# Altium schematic sheet MOTOR.SchDoc
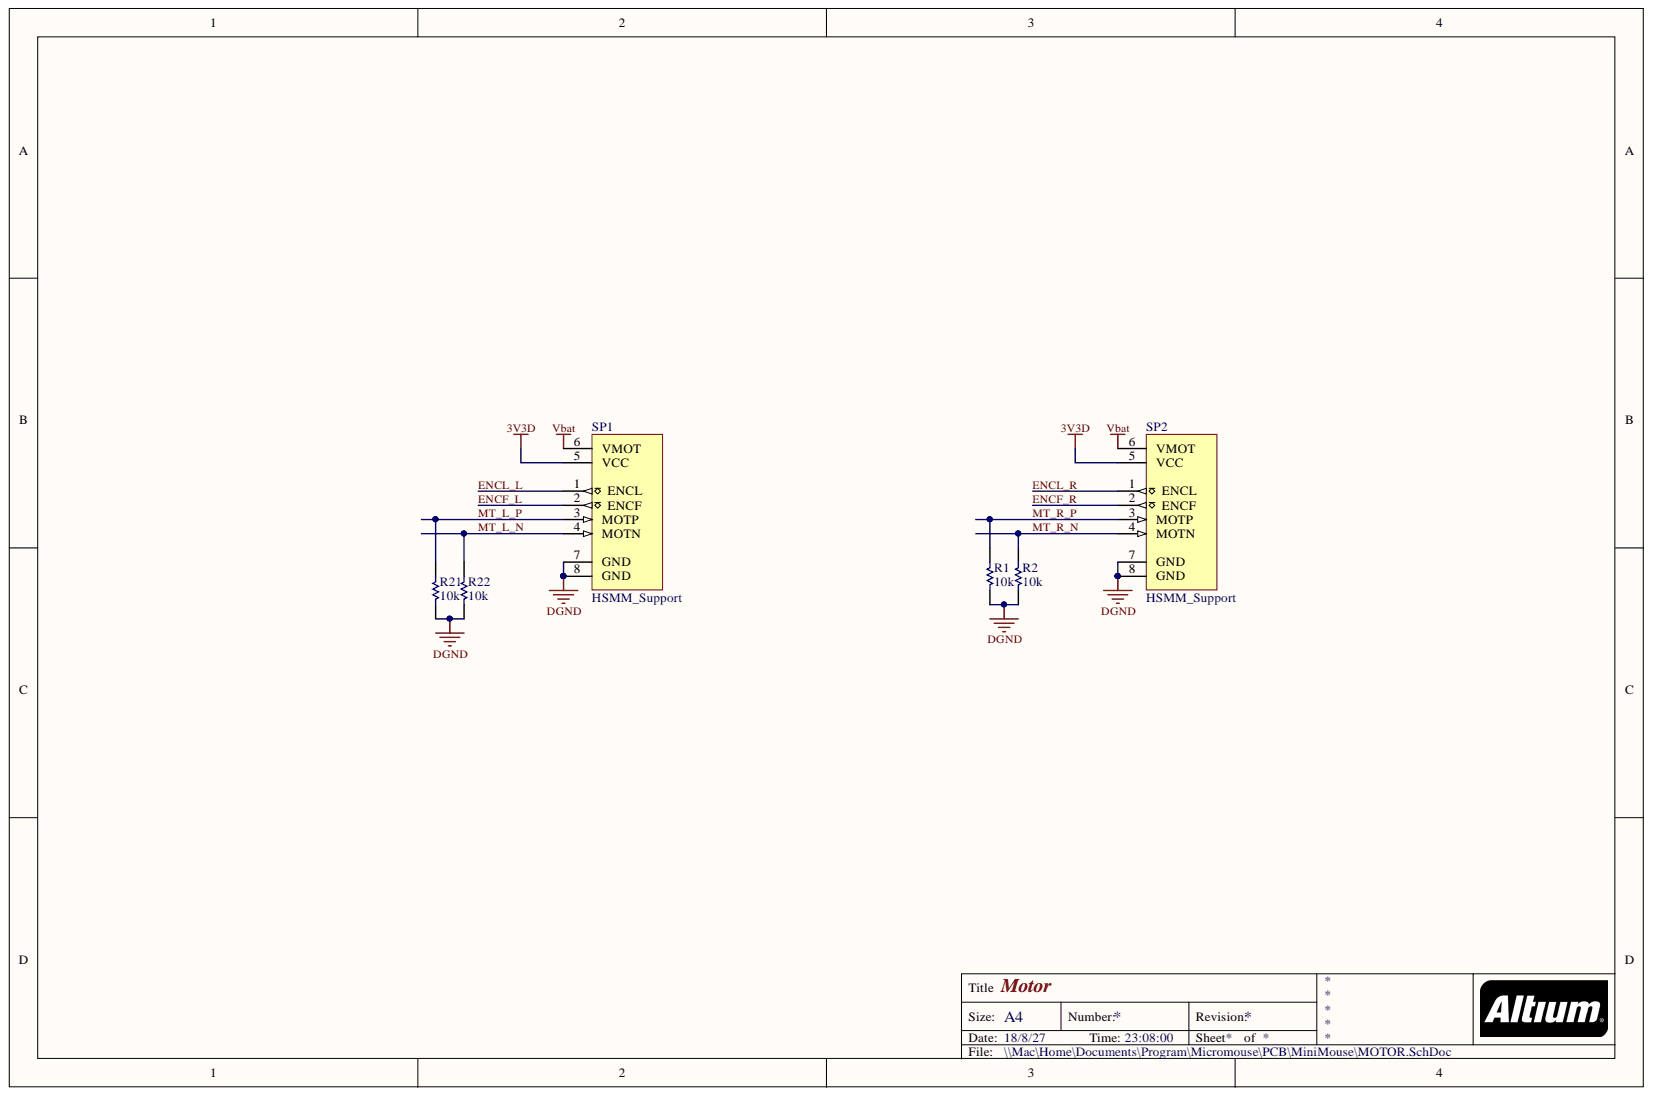
[diagram p0: MOTOR.SchDoc]

## Parts
R1  10k  [RESC1005[0402]]
R2  10k  [RESC1005[0402]]
R21  10k  [RESC1005[0402]]
R22  10k  [RESC1005[0402]]
SP1  HSMM_Support  [HSMM_SupportL]
SP2  HSMM_Support  [HSMM_SupportL]

## Nets
3V3D: SP1.5(VCC) SP2.5(VCC)
DGND: R1.2 R2.2 R21.2 R22.2 SP1.7(GND) SP1.8(GND) SP2.7(GND) SP2.8(GND)
Vbat: SP1.6(VMOT) SP2.6(VMOT)
ENCF_L: SP1.2(ENCF)
ENCF_R: SP2.2(ENCF)
ENCL_L: SP1.1(ENCL)
ENCL_R: SP2.1(ENCL)
MT_L_N: R22.1 SP1.4(MOTN)
MT_L_P: R21.1 SP1.3(MOTP)
MT_R_N: R2.1 SP2.4(MOTN)
MT_R_P: R1.1 SP2.3(MOTP)

## Library data of the parts
R1 R2 R21 R22 = Res1: Resistor; Component Kind: Standard; LatestRevisionDate: 17-Jul-2002; LatestRevisionNote: Re-released for DXP Platform.; Library Name: Miscellaneous Devices.IntLib; PackageDescription: Axial Device, Thru-Hole; 2 Leads; 0.3 in Pin Spacing; PackageReference: AXIAL-0.3; Published: 8-Jun-2000; Signal Integrity: Res; Simulation: RESISTOR; Value: 10k; Publisher: Altium Limited
SP1 SP2 = HSMM_Support: Component Kind: Standard; Library Name: HSMM_Support_Extern.SchLib
